# Altium schematic sheet SCM20100E-Micro.SchDoc(SCM20100E-Micro)
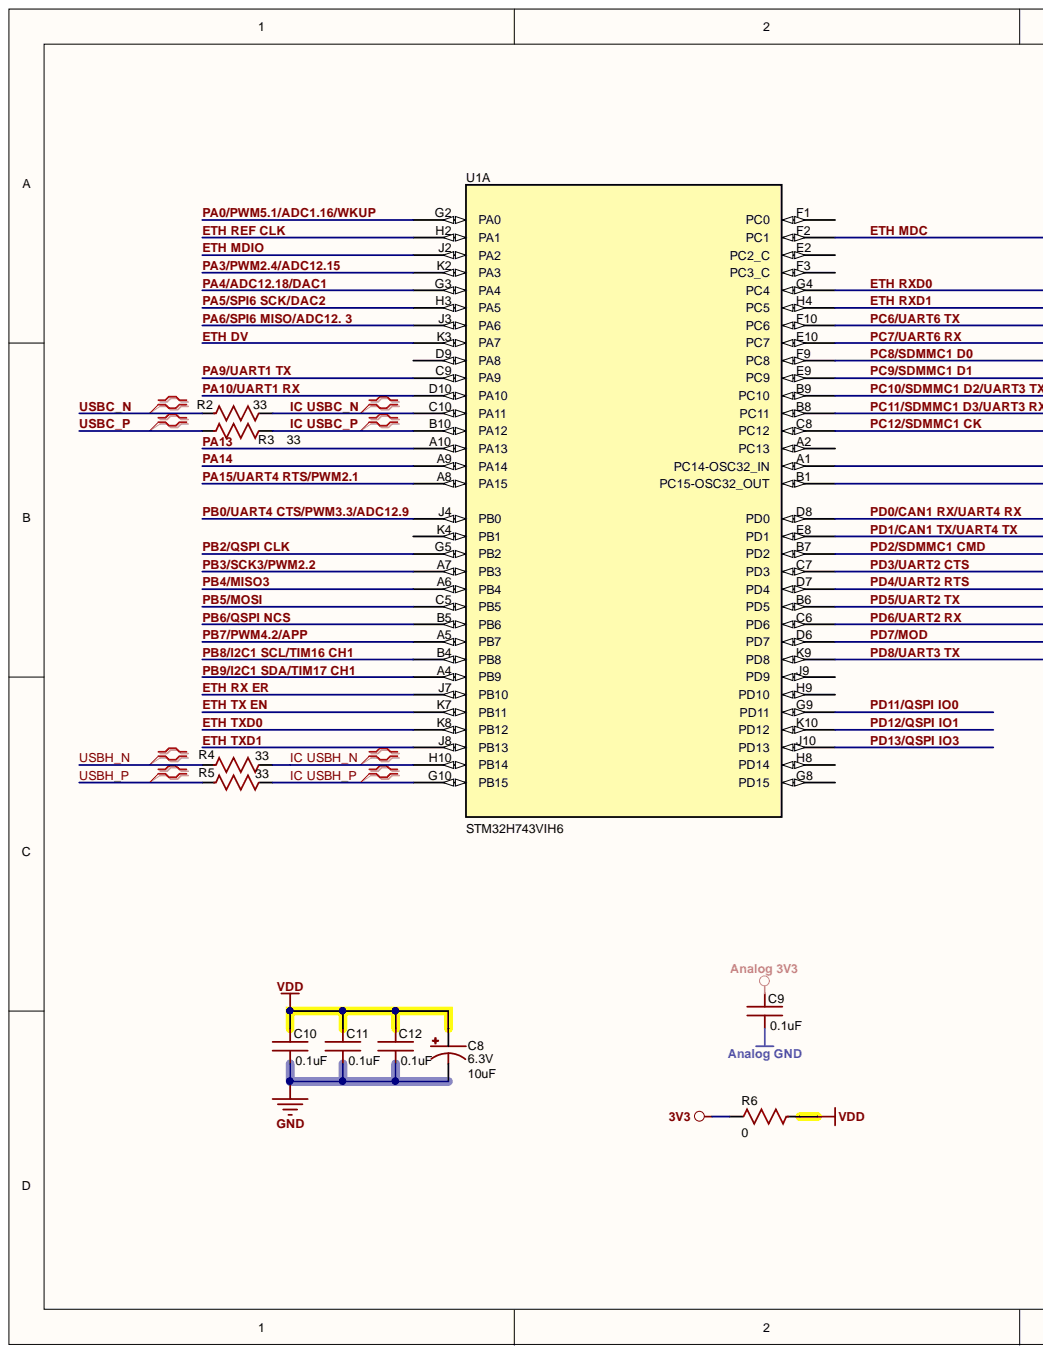
[diagram p0: SCM20100E-Micro.SchDoc, left]
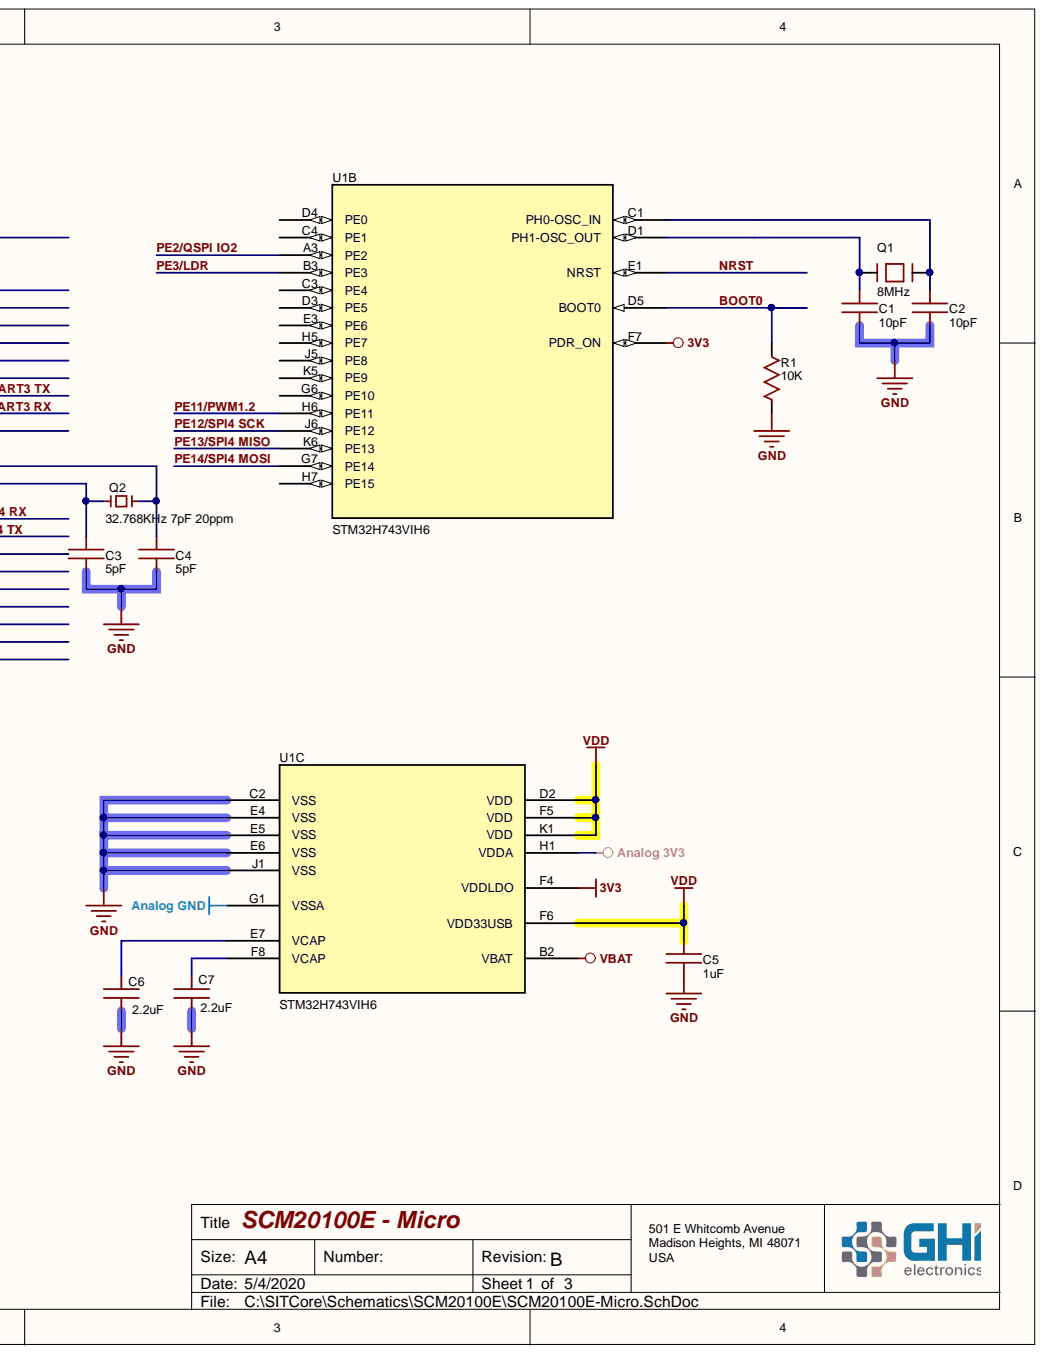
[diagram p1: SCM20100E-Micro.SchDoc, right]

## Parts
C1  value 10pF  [C0402]  (hidden comment: 0402 Cap 10pF)
C2  value 10pF  [C0402]  (hidden comment: 0402 Cap 10pF)
C3  value 5pF  [C0402]  (hidden comment: 0402 Cap 5pF)
C4  value 5pF  [C0402]  (hidden comment: 0402 Cap 5pF)
C5  value 1uF  [C0603 (1608 Metric)]  (hidden comment: 0603 Cap 1uF)
C6  value 2.2uF  [C0603 (1608 Metric)]  (hidden comment: 0603 Cap 2.2uF)
C7  value 2.2uF  [C0603 (1608 Metric)]  (hidden comment: 0603 Cap 2.2uF)
C8  value 10uF  Voltage: 6.3V  [CASE-R 0805 (2012)]  (hidden comment: CASE-R 0805 (2012) Tant 10uF)
C9  value 0.1uF  [C0402]  (hidden comment: 0402 Cap 0.1uF)
C10  value 0.1uF  [C0402]  (hidden comment: 0402 Cap 0.1uF)
C11  value 0.1uF  [C0402]  (hidden comment: 0402 Cap 0.1uF)
C12  value 0.1uF  [C0402]  (hidden comment: 0402 Cap 0.1uF)
Q1  value 8MHz  [CRYSTAL_3.2X2.5]  (hidden comment: CRYSTAL3.2X2.5)
Q2  32.768KHz 7pF 20ppm  Output Frequency: 32.768KHz  [Crystal 3.2mm x 1.5mm]
R1  value 10K  [R0402]  (hidden comment: 0402 Res 10K)
R2  value 33  [R0402]  (hidden comment: 0402 R)
R3  value 33  [R0402]  (hidden comment: 0402 R)
R4  value 33  [R0402]  (hidden comment: 0402 Res 33)
R5  value 33  [R0402]  (hidden comment: 0402 Res 33)
R6  value 0  [R0603 (1608 Metric)]  (hidden comment: 0603 Res 0)
U1  STM32H743VIH6  [TFBGA100]  (parts A,B,C)

## Nets
3V3: R6.2 U1.F4(VDDLDO) U1.F7(PDR_ON)
Analog 3V3: C9.2 U1.H1(VDDA)
Analog GND: C9.1 U1.G1(VSSA)
GND: C1.1 C2.1 C3.1 C4.1 C5.1 C6.1 C7.1 C8.2 C10.1 C11.1 C12.1 R1.1 U1.C2(VSS) U1.E4(VSS) U1.E5(VSS) U1.E6(VSS) U1.J1(VSS)
VBAT: U1.B2(VBAT)
VDD: C5.2 C8.1 C10.2 C11.2 C12.2 R6.1 U1.D2(VDD) U1.F5(VDD) U1.F6(VDD33USB) U1.K1(VDD)
BOOT0: R1.2 U1.D5(BOOT0)
ETH DV: U1.K3(PA7)
ETH MDC: U1.F2(PC1)
ETH MDIO: U1.J2(PA2)
ETH REF CLK: U1.H2(PA1)
ETH RX ER: U1.J7(PB10)
ETH RXD0: U1.G4(PC4)
ETH RXD1: U1.H4(PC5)
ETH TX EN: U1.K7(PB11)
ETH TXD0: U1.K8(PB12)
ETH TXD1: U1.J8(PB13)
IC USBC_N: R2.1 U1.C10(PA11)
IC USBC_P: R3.1 U1.B10(PA12)
IC USBH_N: R4.2 U1.H10(PB14)
IC USBH_P: R5.2 U1.G10(PB15)
NRST: U1.E1(NRST)
PA0/PWM5.1/ADC1.16/WKUP: U1.G2(PA0)
PA3/PWM2.4/ADC12.15: U1.K2(PA3)
PA4/ADC12.18/DAC1: U1.G3(PA4)
PA5/SPI6 SCK/DAC2: U1.H3(PA5)
PA6/SPI6 MISO/ADC12. 3: U1.J3(PA6)
PA9/UART1 TX: U1.C9(PA9)
PA10/UART1 RX: U1.D10(PA10)
PA13: U1.A10(PA13)
PA14: U1.A9(PA14)
PA15/UART4 RTS/PWM2.1: U1.A8(PA15)
PB0/UART4 CTS/PWM3.3/ADC12.9: U1.J4(PB0)
PB2/QSPI CLK: U1.G5(PB2)
PB3/SCK3/PWM2.2: U1.A7(PB3)
PB4/MISO3: U1.A6(PB4)
PB5/MOSI: U1.C5(PB5)
PB6/QSPI NCS: U1.B5(PB6)
PB7/PWM4.2/APP: U1.A5(PB7)
PB8/I2C1 SCL/TIM16 CH1: U1.B4(PB8)
PB9/I2C1 SDA/TIM17 CH1: U1.A4(PB9)
PC6/UART6 TX: U1.F10(PC6)
PC7/UART6 RX: U1.E10(PC7)
PC8/SDMMC1 D0: U1.F9(PC8)
PC9/SDMMC1 D1: U1.E9(PC9)
PC10/SDMMC1 D2/UART3 TX: U1.B9(PC10)
PC11/SDMMC1 D3/UART3 RX: U1.B8(PC11)
PC12/SDMMC1 CK: U1.C8(PC12)
PD0/CAN1 RX/UART4 RX: U1.D8(PD0)
PD1/CAN1 TX/UART4 TX: U1.E8(PD1)
PD2/SDMMC1 CMD: U1.B7(PD2)
PD3/UART2 CTS: U1.C7(PD3)
PD4/UART2 RTS: U1.D7(PD4)
PD5/UART2 TX: U1.B6(PD5)
PD6/UART2 RX: U1.C6(PD6)
PD7/MOD: U1.D6(PD7)
PD8/UART3 TX: U1.K9(PD8)
PD11/QSPI IO0: U1.G9(PD11)
PD12/QSPI IO1: U1.K10(PD12)
PD13/QSPI IO3: U1.J10(PD13)
PE2/QSPI IO2: U1.A3(PE2)
PE3/LDR: U1.B3(PE3)
PE11/PWM1.2: U1.H6(PE11)
PE12/SPI4 SCK: U1.J6(PE12)
PE13/SPI4 MISO: U1.K6(PE13)
PE14/SPI4 MOSI: U1.G7(PE14)
USBC_N: R2.2
USBC_P: R3.2
USBH_N: R4.1
USBH_P: R5.1
NetC1_2: C1.2 Q1.1 U1.D1(PH1-OSC_OUT)
NetC2_2: C2.2 Q1.3 U1.C1(PH0-OSC_IN)
NetC3_2: C3.2 Q2.1 U1.B1(PC15-OSC32_OUT)
NetC4_2: C4.2 Q2.2 U1.A1(PC14-OSC32_IN)
NetC6_2: C6.2 U1.E7(VCAP)
NetC7_2: C7.2 U1.F8(VCAP)

Not connected: U1 A2(PC13) C3(PE4) C4(PE1) D3(PE5) D4(PE0) D9(PA8) E2(PC2_C) E3(PE6) F1(PC0) F3(PC3_C) G6(PE10) G8(PD15) H5(PE7) H7(PE15) H8(PD14) H9(PD10) J5(PE8) J9(PD9) K4(PB1) K5(PE9)

## Library data of the parts
C1 C2 = 0402 Cap 10pF: 10pF ±0.5pF 50V C0G 0402 Multilayer Ceramic Capacitors MLCC - SMD/SMT RoHS; Mfr.Part #: CL05C100DB5NNNC; Manufacturer: Samsung Electro-Mechanics; Voltage: 50V; Tolerance: ±0.5pF; Package: 0402; LCSC Part #: C307440; Component Kind: Standard; Category: Ceramic; Capacitance: 10pF; Library Name: GHI-Passives.SchLib
C3 C4 = 0402 Cap 5pF: 5pF ±0.1pF 50V C0G 0402 Multilayer Ceramic Capacitors MLCC - SMD/SMT RoHS; Mfr.Part #: CL05C050BB5NNNC; Manufacturer: Samsung Electro-Mechanics; Voltage: 50V; Tolerance: ±0.1pF; Package: 0402; LCSC Part #: C318616; Component Kind: Standard; Category: Ceramic; Capacitance: 5pF; Library Name: GHI-Passives.SchLib
C5 = 0603 Cap 1uF: 2.2uF ±10% 10V X5R 0603 Multilayer Ceramic Capacitors MLCC - SMD/SMT RoHS; Mfr.Part #: CC0603KRX5R7BB105; Manufacturer: YAGEO; Voltage: 16V; Tolerance: ±10%; Package: 0603; LCSC Part #: C106215; Component Kind: Standard; Category: Ceramic; Capacitance: 1uF; Library Name: GHI-Passives.SchLib
C6 C7 = 0603 Cap 2.2uF: 2.2uF ±10% 10V X5R 0603 Multilayer Ceramic Capacitors MLCC - SMD/SMT RoHS; Mfr.Part #: CC0603KRX5R6BB225; Manufacturer: YAGEO; Voltage: 10V; Tolerance: ±10%; Package: 0603; LCSC Part #: C106213; Component Kind: Standard; Category: Ceramic; Capacitance: 2.2uF; Library Name: GHI-Passives.SchLib
C8 = CASE-R 0805 (2012) Tant 10uF: 10uF ±10% 6.3V 6 Ω @ 100kHz -55℃ ~ +125℃ CASE-R_2012 Tantalum Capacitors RoHS; Mfr.Part #: TAJR106K006RNJ; Manufacturer: AVX; Tolerance: ±10%; Package: CASE-N_2012; LCSC Part #: C132274; Digikey: 478-3273-2-ND; Component Kind: Standard; Category: Tantalum; Capacitance: 10uF; Library Name: GHI-Passives.SchLib
C9-C12 = 0402 Cap 0.1uF: 0.1uF ±10% 16V X7R 0402 Multilayer Ceramic Capacitors MLCC - SMD/SMT RoHS; Mfr.Part #: CC0402KRX7R7BB104; Voltage: 16V; Tolerance: ±10%; Package: 0402; LCSC Part #: C60474; Component Kind: Standard; Category: Ceramic; Capacitance: 0.1uF; Library Name: GHI-Passives.SchLib
Q1 = CRYSTAL3.2X2.5: 4 pad 3.2 mm x 2.5 mm Crystal; SymbolName_1: CRYSTAL.2PAD; GateName_1: G$1; LibraryName: GHI2; DeviceSetName: CRYSTAL3.2X2.5; Component Kind: Standard; Library Name: GHI.SchLib
Q2 = 32.768KHz 7pF 20ppm 2 Pad 3.2mm x 1.5mm: Crystal 2 Pad 3.2mm x 1.5mm 32.768KHz; Manufacturer Part Number: 6K30032768K0702070401; GHI Part Number: EPC-Q32KHZ; Shunt Capacitance: 1.3pF Typ; Mode: AT/Fundamental; Load Capacitance: 10 pF; Frequency Tolerance: +- 20ppm; Equivalent Series Resistance: 70k Ohm Max; Driver Level (Typical): 1uW Max; Aging @25 C 1st Year (Max): +- 3ppm/year; Storage Temperature: -55C to +125 C; Shock Resistance: N/A; Operating Temperature Range: -40 C to +85 C; Library Name: GHI Oscillators.SchLib; Frequency Stability: N/A; Component Kind: Standard
R1 = 0402 Res 10K: 10K ±1% 1/16W ±100ppm/℃ 0402 Chip Resistor - Surface Mount RoHS; Mfr.Part #: RC0402FR-0710KL; Manufacturer: YAGEO; Voltage Rating: *V; Resistance Tolerance: ±1%; Resistance: 10K; Power Rating: 1/16W; Package: 0402; LCSC Part #: C60490; Component Kind: Standard; Library Name: GHI-Passives.SchLib
R2-R5 = 0402 Res 33: 33 ±1% 1/16W ±100ppm/℃ 0402 Chip Resistor - Surface Mount RoHS; Mfr.Part #: RC0402FR-0733RL; Manufacturer: YAGEO; Voltage Rating: *V; Resistance Tolerance: ±1%; Resistance: 33; Power Rating: 1/16W; Package: 0402; LCSC Part #: C138002; Component Kind: Standard; Library Name: GHI-Passives.SchLib
R6 = 0603 Res 0: ±5% 1/10W 0603 Chip Resistor - Surface Mount RoHS; Mfr.Part #: RC0603JR-070RL; Manufacturer: YAGEO; Voltage Rating: *V; Resistance Tolerance: ±5%; Resistance: 0; Power Rating: 1/10W; Package: 0603; LCSC Part #: C95177; Component Kind: Standard; Library Name: GHI-Passives.SchLib
U1 = STM32H7_TFBGA100: No Description Available; Manufacturer_Part_Number: stm32h7_tfbga100_t1; Vendor: STMicroelectronics; Value: STM32H743VIH6; Type: DEV; RefDes: RefDes; Component Kind: Standard; Library Name: GHI-Integrated Circuits.SchLib; Copyright: Copyright (C) 2018 Accelerated Designs. All rights reserved
